# Altium schematic sheet PowerSupply.SchDoc(PowerSupply)
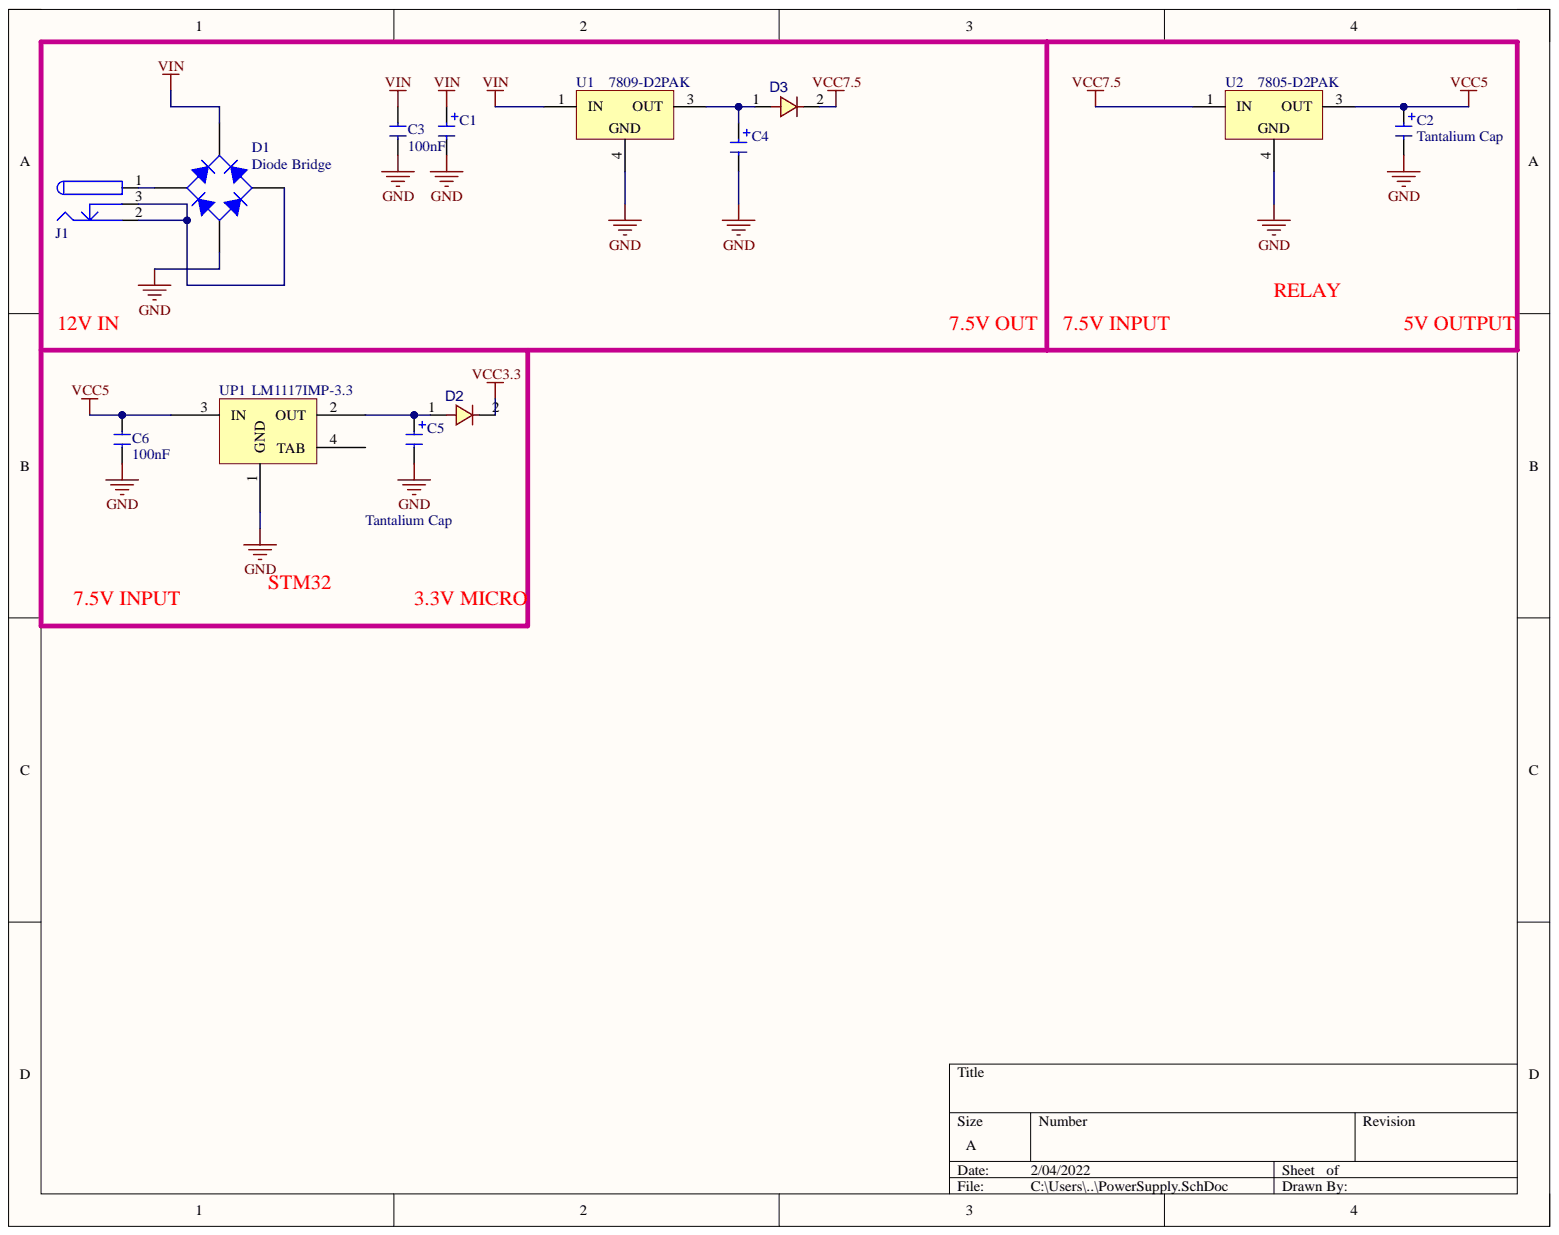
[diagram p0: PowerSupply.SchDoc]

## Parts
C1  (no value)  [CAPACITOR RADIAL SMT size F]
C2  Tantalium Cap  [Tantalium Size B]
C3  Capacitor SMD  [1206 Capacitor]  (value not drawn)
C4  (no value)  [CAPACITOR RADIAL SMT size F]
C5  Tantalium Cap  [Tantalium Size B]
C6  Capacitor SMD  [1206 Capacitor]  (value not drawn)
D1  Diode Bridge  [Bridge Circle Package]
D2  =VALUE  [D_SOD80]
D3  =VALUE  [D_SOD80]
J1  DC Power Jack  [DC Power Jack 1]  (value not drawn)
U1  7809-D2PAK  [D2PAK TO-263]
U2  7805-D2PAK  [D2PAK TO-263]
UP1  value LM1117IMP-3.3  [SOT223_4]  (hidden comment: LM1117IMP-5.0)

## Nets
GND: C1.2 C2.2 C3.1 C4.2 C5.2 C6.1 D1.3 U1.4 U2.4 UP1.1(GND)
VCC3.3: D2.2
VCC5: C2.1 C6.2 U2.3 UP1.3(IN)
VCC7.5: D3.2 U2.1
VIN: C1.1 C3.2 D1.1 U1.1
NetC4_1: C4.1 D3.1 U1.3
NetC5_1: C5.1 D2.1 UP1.2(OUT)
NetD1_2: D1.2 J1.1
NetD1_4: D1.4 J1.2 J1.3

Not connected: UP1 4(TAB)

## Library data of the parts
C1 C2 C4 C5 = Capacitor Tantalium: Component Kind: Standard; Library Name: ZetaBoard_Lib.SchLib
D1 = Diode Bridge TOTAL SIZE: Full Wave Diode Bridge; Designer: Abbas Basereh; Component Kind: Standard; ComponentLink1Description: Datasheet; ComponentLink2Description: Reference; Design Date: 1395/11/09; Library Name: Altium.IntLib; Mounting Technology: Surface Mount\Through-Hole; Status: Unreleased
D2 D3 = DIODE_SMD_1N4148_SOD80: DIODE, FAST SWTICHING, 0.3A, 0.5W; DESC: DESCRIPTION; MANF_P#: 1N4148; PARAM: 75V; PARAM2: 300mA, 0.5W; ASSEMBLY: ASSEMBLY; Component Kind: Standard; Library Name: diode.SchLib; MANF: MANF; PRICE: PRICE
J1 = DC Power Jack: DC Power Jack. Center Pin 2.5mm. Diameter: 5.7mm; Company: Personal; Designer: Ali Hafizi; Project Name: Laser; Adding Date: 10/21/2009; Component Kind: Standard; Library Name: Altium.IntLib
U1 = 7805-D2PAK: Component Kind: Standard; Library Name: ZetaBoard_Lib.SchLib
UP1 = LM1117IMP-x.x: x.x Volt, 800mA Low-Dropout Linear Regulator, 4-pin SOT-223; Designer: Abbas Basereh; Component Kind: Standard; Data: 16-12-2016; Library Name: 2017AbbasBasereh9365731239 -ver1.IntLib
C3 C6 = Capacitor SMD: Component Kind: Standard; Library Name: ZetaBoard_Lib.SchLib
U2 = 7805-D2PAK: Component Kind: Standard; Library Name: ZetaBoard_Lib.SchLib
